# Altium schematic sheet 硬件二值化.SchDoc(硬件二值化)
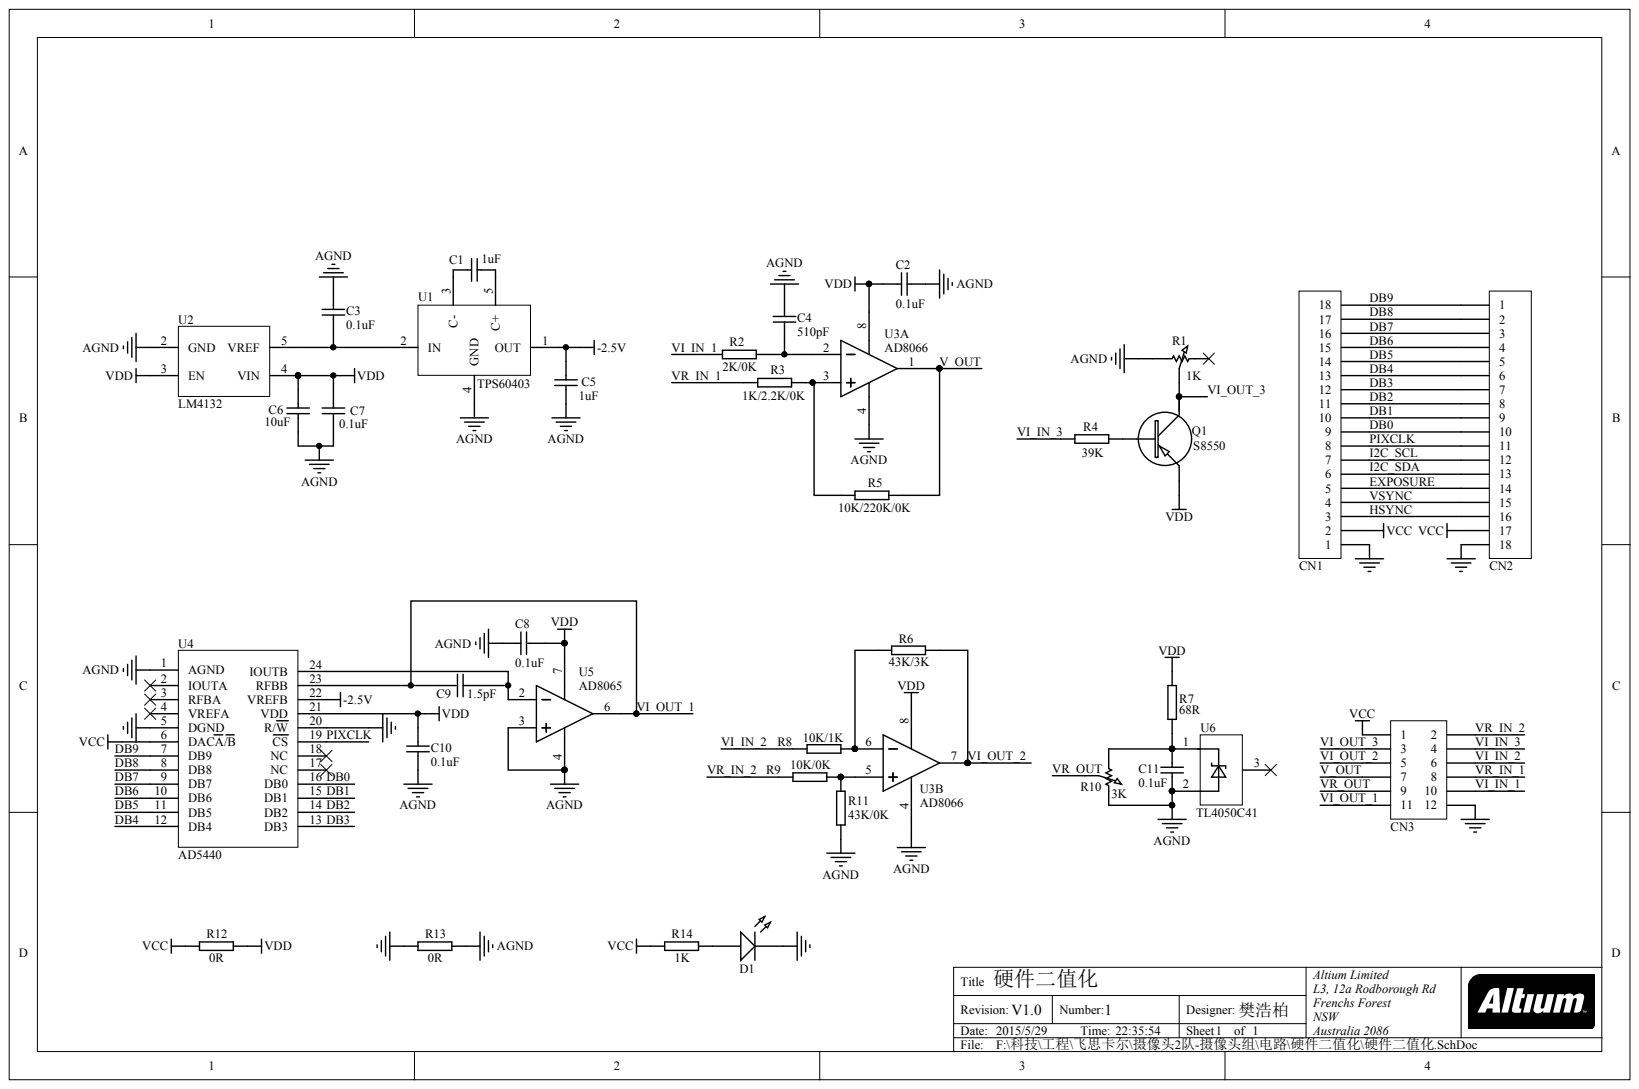
[diagram p0: 硬件二值化.SchDoc]

## Parts
C1  1uF
C2  0.1uF
C3  0.1uF
C4  510pF
C5  1uF
C6  10uF
C7  0.1uF
C8  0.1uF
C9  1.5pF
C10  0.1uF
C11  0.1uF
CN1  CON-18X1  (value not drawn)
CN2  CON-18X1  (value not drawn)
CN3  CON-6X2  (value not drawn)
D1  LED  (value not drawn)
Q1  S8550
R1  1K
R2  2K/0K
R3  1K/2.2K/0K
R4  39K
R5  10K/220K/0K
R6  43K/3K
R7  68R
R8  10K/1K
R9  10K/0K
R10  3K
R11  43K/0K
R12  0R
R13  0R
R14  1K
U1  TPS60403
U2  LM4132
U3  AD8066  (parts A,B)
U4  AD5440
U5  AD8065
U6  TL4050C41

## Nets
-2.5V: C5.1 U1.1(OUT) U4.22(VREFB)
AGND: C2.2 C3.2 C4.2 C5.2 C6.2 C7.2 C8.2 C10.1 C11.1 R1.1 R10.3 R11.1 R13.2 U1.4(GND) U2.2(GND) U3.4 U4.1(AGND) U5.3 U5.4 U6.2
GND: CN1.1 CN2.18 CN3.12 D1.K R13.1 U4.5(DGND) U4.20(R/W)
VCC: CN1.2 CN2.17 CN3.1 R12.1 R14.1 U4.6(DACA/B)
VDD: C2.1 C6.1 C7.1 C8.1 C10.2 Q1.2 R7.2 R12.2 U2.3(EN) U2.4(VIN) U3.8 U4.21(VDD) U5.7
DB0: CN1.9 CN2.10 U4.16(DB0)
DB1: CN1.10 CN2.9 U4.15(DB1)
DB2: CN1.11 CN2.8 U4.14(DB2)
DB3: CN1.12 CN2.7 U4.13(DB3)
DB4: CN1.13 CN2.6 U4.12(DB4)
DB5: CN1.14 CN2.5 U4.11(DB5)
DB6: CN1.15 CN2.4 U4.10(DB6)
DB7: CN1.16 CN2.3 U4.9(DB7)
DB8: CN1.17 CN2.2 U4.8(DB8)
DB9: CN1.18 CN2.1 U4.7(DB9)
EXPOSURE: CN1.5 CN2.14
HSYNC: CN1.3 CN2.16
I2C_SCL: CN1.7 CN2.12
I2C_SDA: CN1.6 CN2.13
PIXCLK: CN1.8 CN2.11 U4.19(CS)
V_OUT: CN3.7 R5.1 U3.1
VI_IN_1: CN3.10 R2.1
VI_IN_2: CN3.6 R8.1
VI_IN_3: CN3.4 R4.1
VI_OUT_1: C9.2 CN3.11 U4.23(RFBB) U5.6
VI_OUT_2: CN3.5 R6.2 U3.7
VI_OUT_3: CN3.3 Q1.3 R1.2
VR_IN_1: CN3.8 R3.2
VR_IN_2: CN3.2 R9.1
VR_OUT: CN3.9 R10.2
VSYNC: CN1.4 CN2.15
NetC1_1: C1.1 U1.5(C+)
NetC1_2: C1.2 U1.3(C-)
NetC3_1: C3.1 U1.2(IN) U2.5(VREF)
NetC4_1: C4.1 R2.2 U3.2
NetC9_1: C9.1 U4.24(IOUTB) U5.2
NetC11_2: C11.2 R7.1 R10.1 U6.1
NetD1_A: D1.A R14.2
NetQ1_1: Q1.1 R4.2
NetR3_1: R3.1 R5.2 U3.3
NetR6_1: R6.1 R8.2 U3.6
NetR9_2: R9.2 R11.2 U3.5

Not connected: R1 3; U4 2(IOUTA) 3(RFBA) 4(VREFA) 17(NC) 18(NC); U6 3
